# Altium schematic sheet ADC.SchDoc(ADC)
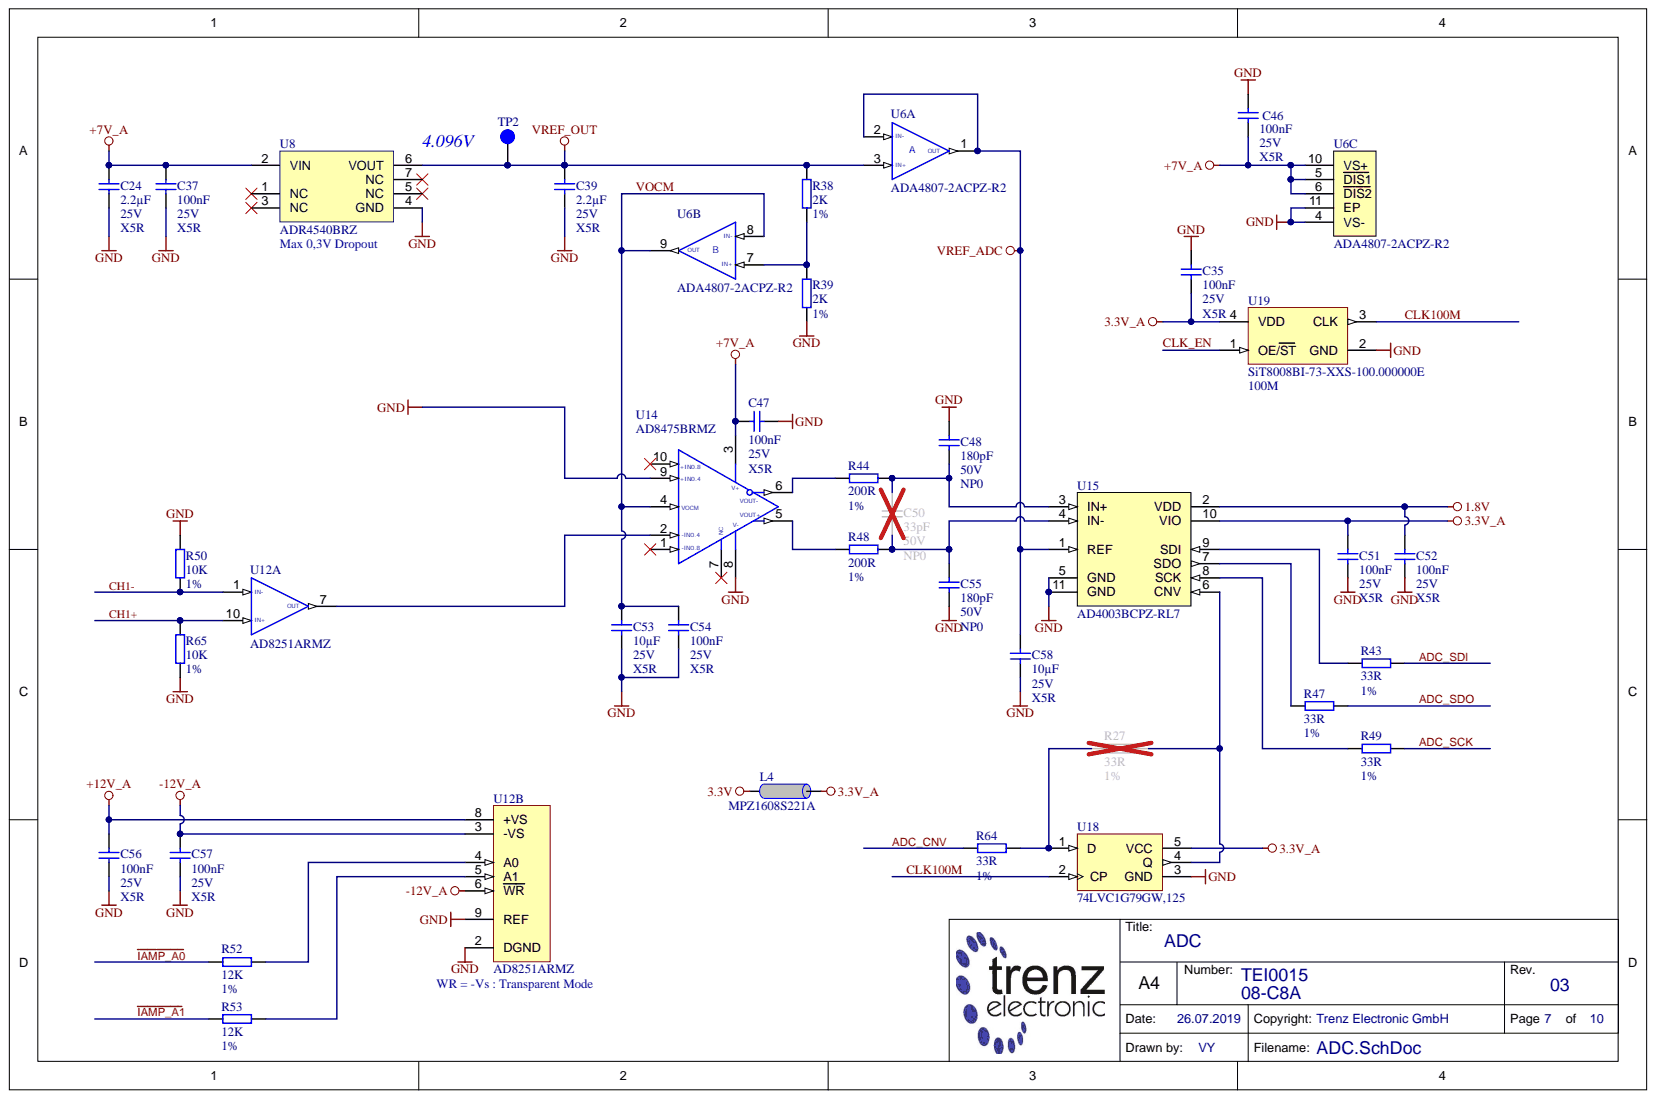
[diagram p0: ADC.SchDoc]

## Parts
C24  2.2µF  Technology: X5R  Voltage: 25V  [CAPC1608X90N]
C35  100nF  Voltage: 25V  [CAPC1005X55N]
C37  100nF  Technology: X5R  Voltage: 25V  [CAPC1005X55N]
C39  2.2µF  Technology: X5R  Voltage: 25V  [CAPC1608X90N]
C46  100nF  Technology: X5R  Voltage: 25V  [CAPC1005X55N]
C47  100nF  [CAPC1005X55N]
C48  180pF  Technology: NP0  Voltage: 50V  [CAPC1005X55N]
C50  33pF  Voltage: 50V  [CAPC1005X55N]
C51  100nF  Technology: X5R  Voltage: 25V  [CAPC1005X55N]
C52  100nF  Technology: X5R  Voltage: 25V  [CAPC1005X55N]
C53  10µF  Technology: X5R  Voltage: 25V  [CAPC2012X135N]
C54  100nF  Technology: X5R  Voltage: 25V  [CAPC1005X55N]
C55  180pF  Technology: NP0  Voltage: 50V  [CAPC1005X55N]
C56  100nF  Technology: X5R  Voltage: 25V  [CAPC1005X55N]
C57  100nF  Technology: X5R  Voltage: 25V  [CAPC1005X55N]
C58  10µF  Technology: X5R  Voltage: 25V  [CAPC2012X135N]
L4  MPZ1608S221A  [INDC1608X95N]
R27  33R  Tolerance: 1%  [RESC1005X40N]
R38  2K  Tolerance: 1%  [RESC1005X40N]
R39  2K  Tolerance: 1%  [RESC1005X40N]
R43  33R  Tolerance: 1%  [RESC1005X40N]
R44  200R  Tolerance: 1%  [RESC1005X40N]
R47  33R  Tolerance: 1%  [RESC1005X40N]
R48  200R  Tolerance: 1%  [RESC1005X40N]
R49  33R  Tolerance: 1%  [RESC1005X40N]
R50  10K  Tolerance: 1%  [RESC1005X40N]
R52  12K  [RESC1005X40N]
R53  12K  Tolerance: 1%  [RESC1005X40N]
R64  33R  Tolerance: 1%  [RESC1005X40N]
R65  10K  Tolerance: 1%  [RESC1005X40N]
TP2  Testpoint 0.8mm  [TP_0.8mm]  (value not drawn)
U6  ADA4807-2ACPZ-R2  [SON50P300X300X80-11T164]  (parts A,B,C)
U8  ADR4540BRZ  Voltage: 4.096V  [SOIC127P600X175-8N]
U12  AD8251ARMZ  [SOP50P490X110-10N]  (parts A,B)
U14  AD8475BRMZ  [SOP50P490X110-10N]
U15  AD4003BCPZ-RL7  [SON50P300X300X80-11T164]
U18  74LVC1G79GW,125  [SOT65P210X110-5N]
U19  SiT8008BI-73-XXS-100.000000E  value 100M  Database Table Name: CLK  [2016]

## Nets
1.8V: C52.1 U15.2(VDD)
3.3V: L4.1
3.3V_A: C35.1 C51.1 L4.2 U15.10(VIO) U18.5(VCC) U19.4(VDD)
+7V_A: C24.1 C37.1 C46.1 C47.1 U6.5(DIS1) U6.6(DIS2) U6.10(VS+) U8.2(VIN) U14.3
+12V_A: C56.1 U12.8(+VS)
-12V_A: C57.1 U12.3(-VS) U12.6(WR)
GND: C24.2 C35.2 C37.2 C39.2 C46.2 C47.2 C48.1 C51.2 C52.2 C53.2 C54.2 C55.2 C56.2 C57.2 C58.2 R39.2 R50.1 R65.2 U6.4(VS-) U6.11(EP) U8.4(GND) U12.2(DGND) U12.9(REF) U14.8 U14.9(+IN0.4) U15.5(GND) U15.11(GND) U18.3(GND) U19.2(GND)
VREF_ADC: C58.1 U6.1(OUT) U6.2(IN-) U15.1(REF)
VREF_OUT: C39.1 R38.1 TP2.1 U6.3(IN+) U8.6(VOUT)
~{IAMP_A1}: R53.1
ADC_CNV: R64.2
ADC_SCK: R49.2
ADC_SDI: R43.2
ADC_SDO: R47.2
CH1+: R65.1 U12.10(IN+)
CH1-: R50.2 U12.1(IN-)
CLK100M: U18.2(CP) U19.3(CLK)
CLK_EN: U19.1(OE/ST)
~{IAMP_A0}: R52.1
VOCM: C53.1 C54.1 U6.8(IN-) U6.9(OUT) U14.4(VOCM)
NetC48_2: C48.2 C50.1 R44.2 U15.3(IN+)
NetC50_2: C50.2 C55.1 R48.2 U15.4(IN-)
NetR27_1: R27.1 U15.6(CNV) U18.4(Q)
NetR27_2: R27.2 R64.1 U18.1(D)
NetR38_2: R38.2 R39.1 U6.7(IN+)
NetR43_1: R43.1 U15.9(SDI)
NetR44_1: R44.1 U14.6
NetR47_1: R47.1 U15.7(SDO)
NetR48_1: R48.1 U14.5
NetR49_1: R49.1 U15.8(SCK)
NetR52_2: R52.2 U12.4(A0)
NetR53_2: R53.2 U12.5(A1)
NetU12_7: U12.7(OUT) U14.2(-IN0.4)

Not connected: U8 1(NC) 3(NC) 5(NC) 7(NC); U14 1(-IN0.8) 7(NC) 10

## Library data of the parts
C24 C39 = 27910: KON 2.2uF 25V X5R ±10% SMD BF 1608 (0603); Database Table Name: C_1608_0603; Mixed: SMT; Temperature-Range: -55/+85; Value: 2.2u; AN: 27910; Case: 1608 (0603); Component Kind: Standard; Library Name: TE.DbLib; Tolerance: ±10%
C35 C37 C46 C47 C51 C52 C54 C56 C57 = 22472: KON 100nF 25V X5R ±10% SMD BF 1005 (0402); Database Table Name: C_1005_0402; Mixed: SMT; Preferred: Yes; Temperature-Range: -55/+85; Value: 100n; AN: 22472; Case: 1005 (0402); Component Kind: Standard; Library Name: TE.DbLib
  C35: Technology: X5R
  C47: Technology: X5R; Voltage: 25V
C48 C55 = 24855: KON 180pF 50V NP0 SMD BF 1005 (0402); Database Table Name: C_1005_0402; Mixed: SMT; Value: 180p; AN: 24855; Case: 1005 (0402); Component Kind: Standard; Library Name: TE.DbLib
C50 = 23992: KON 33pF 50V NP0 5% SMD BF 1005 (0402); Database Table Name: C_1005_0402; Mixed: SMT; Preferred: Yes; Value: 33p; AN: 23992; Case: 1005 (0402); Component Kind: Standard; Library Name: TE.DbLib; Technology: NP0; Tolerance: 5%
C53 C58 = 25567: KON 10µF 25V X5R 10% SMD BF 2012 (0805); Database Table Name: C_2012_0805; Mixed: SMT; Temperature-Range: -55/+85; Value: 10u; AN: 25567; Case: 2012 (0805); Component Kind: Standard; Library Name: TE.DbLib; Tolerance: 10%
L4 = 22718: Ferritdurchführung MPZ1608S221A; Database Table Name: L_1608_0603; Mixed: SMT; Preferred: Yes; Value: 220 OHm @ 100MHz; AN: 22718; Case: 1608 (0603); Component Kind: Standard; H-ART: MPZ1608S221A; Library Name: TE.DbLib; Trenz-Stock: Yes; HelpURL: B:\AD\adlib\datasheet\MPZ1608
R27 R43 R47 R49 R64 = 22770: WID SMD 33R 1% 0,063W BF 1005 (0402); Database Table Name: R_1005_0402; H-ART: RC0402FR-0733RL; Mixed: SMT; Preferred: Yes; Value: 33; AN: 22770; Case: 1005 (0402); Component Kind: Standard; Library Name: TE.DbLib; Technology: TK100
R38 R39 = 25073: WID SMD 2K 1% 0,063W  BF 1005 (0402); Database Table Name: R_1005_0402; H-ART: RC0402FR-072KL; Mixed: SMT; Preferred: Yes; AN: 25073; Case: 1005 (0402); Component Kind: Standard; Library Name: TE.DbLib; Technology: TK100; Value: 2K
R44 R48 = 22758: WID SMD 200R 1% 0,063W BF 1005 (0402); Database Table Name: R_1005_0402; Mixed: SMT; Preferred: Yes; Value: 200; AN: 22758; Case: 1005 (0402); Component Kind: Standard; Library Name: TE.DbLib; Technology: TK100
R50 R65 = 22750: WID SMD 10K 1% 0,063W BF 1005 (0402); Database Table Name: R_1005_0402; H-ART: RC0402FR-0710KL; Mixed: SMT; Preferred: Yes; AN: 22750; Case: 1005 (0402); Component Kind: Standard; Library Name: TE.DbLib; Technology: TK100; Value: 10K
R52 R53 = 22598: WID SMD 12K 1% 0,063W  BF 1005 (0402); Database Table Name: R_1005_0402; Mixed: SMT; Preferred: Yes; AN: 22598; Case: 1005 (0402); Component Kind: Standard; Library Name: TE.DbLib; Technology: TK100; Value: 12K
  R52: Tolerance: 1%
TP2 = 26289: Database Table Name: PCB; Mixed: MECH; AN: 26289; Component Kind: Standard; Description: Testpoint 0.8mm; Library Name: TE.DbLib
U6 = 28289: Database Table Name: OP; Mixed: SMT; AN: 28289; Case: DFN10; Component Kind: Standard; Description: ADA4807-2ACPZ-R2; H-ART: ADA4807-2ACPZ-R2; Library Name: TE.DbLib; HelpURL: b:\AD\adlib\datasheet\ADA4807\
U8 = 28384: Database Table Name: REF; Mixed: SMT; AN: 28384; Case: SO8; Component Kind: Standard; Description: ADR4540BRZ; H-ART: ADR4540BRZ; Library Name: TE.DbLib; HelpURL: b:\AD\adlib\datasheet\ADR45xx\
U12 = 24587: Database Table Name: OP; Mixed: SMT; Trenz-Stock: Yes; AN: 24587; Case: MSOP10; Component Kind: Standard; Description: AD8251ARMZ; H-ART: AD8251ARMZ; Library Name: TE.DbLib; HelpURL: B:\AD\adlib\datasheet\AD8251
U14 = 28209: Database Table Name: OP; Mixed: SMT; AN: 28209; Case: MSOP10; Component Kind: Standard; Description: AD8475BRMZ; H-ART: AD8475BRMZ; Library Name: TE.DbLib; HelpURL: b:\AD\adlib\datasheet\AD8475\
U15 = 28116: Database Table Name: ADC; Mixed: SMT; AN: 28116; Case: DFN10; Component Kind: Standard; Description: AD4003BCPZ-RL7; H-ART: AD4003BCPZ-RL7; Library Name: TE.DbLib; HelpURL: b:\AD\adlib\datasheet\AD4003\
U18 = 29689: Database Table Name: LOGIC; Mixed: SMT; Temperature-Range: -40/+125; AN: 29689; Case: SC70-5; Component Kind: Standard; Description: 74LVC1G79GW,125; H-ART: 74LVC1G79GW,125; Library Name: TE.DbLib; Storage-Temperature: -65/+150(Abs); HelpURL: b:\AD\adlib\datasheet\74LVC1G79\
U19 = 25961: SiT8008BI-73-XXS-100.000000E MEMS Oszillator 100MHz; Mixed: SMT; Preferred: Yes; AN: 25961; Case: 2.0  x 1.6mm; Component Kind: Standard; H-ART: SiT8008BI-73-XXS-100.000000E; Library Name: TE.DbLib; Trenz-Stock: Yes; HelpURL: B:\AD\adlib\datasheet\SiT8008
